# Altium schematic sheet CAO_DMX.SchDoc
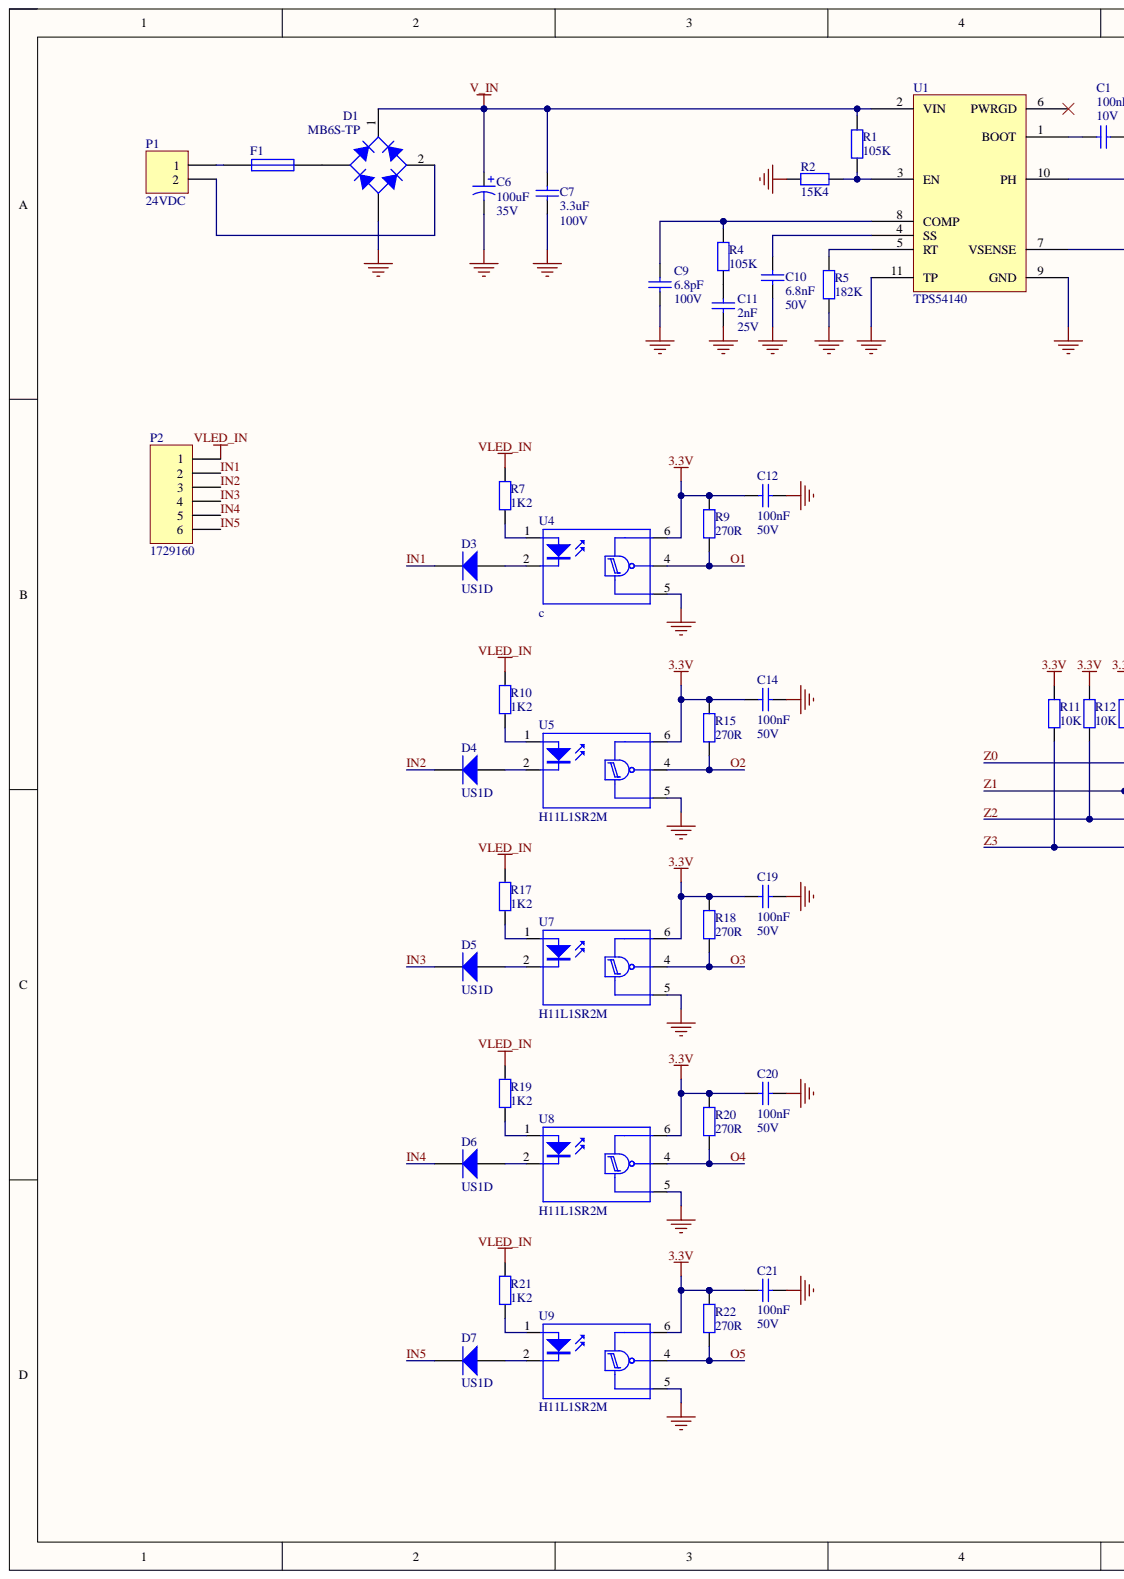
[diagram p0: CAO_DMX.SchDoc, left]
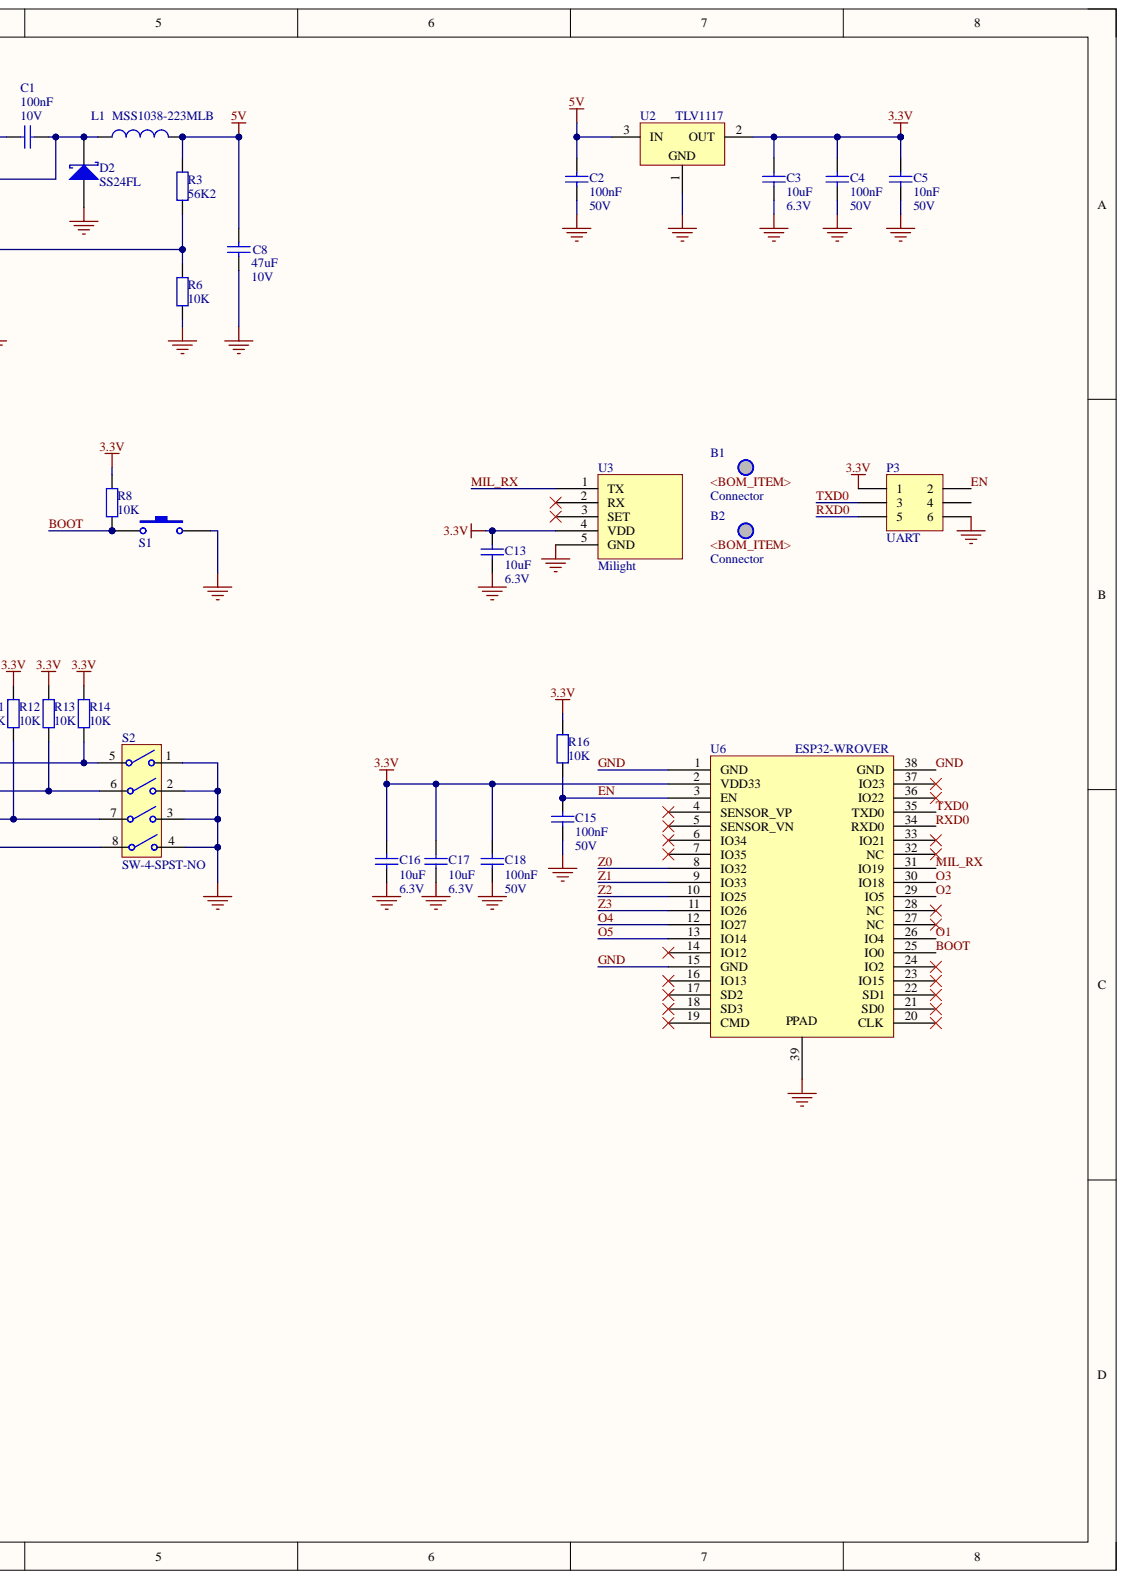
[diagram p1: CAO_DMX.SchDoc, right]

## Parts
B1  Connector  (mechanical)
B2  Connector  (mechanical)
C1  Value 1: 100nF  Value 2: 10V  [CAPC1608N]  (hidden comment: Capacitor)
C2  Value 1: 100nF  Value 2: 50V  [CAPC1608N]  (hidden comment: Capacitor)
C3  Value 1: 10uF  Value 2: 6.3V  [CAPC2012N]  (hidden comment: Capacitor)
C4  Value 1: 100nF  Value 2: 50V  [CAPC1608N]  (hidden comment: Capacitor)
C5  Value 1: 10nF  Value 2: 50V  [CAPC1608N]  (hidden comment: Capacitor)
C6  Value 1: 100uF  Value 2: 35V  [ECAP_SMD_6.3]  (hidden comment: Polarized Capacitor)
C7  Value 1: 3.3uF  Value 2: 100V  [CAPC3216N]  (hidden comment: Capacitor)
C8  Value 1: 47uF  Value 2: 10V  [CAPC2012N]  (hidden comment: Capacitor)
C9  Value 1: 6.8pF  Value 2: 100V  [CAPC1608N]  (hidden comment: Capacitor)
C10  Value 1: 6.8nF  Value 2: 50V  [CAPC1608N]  (hidden comment: Capacitor)
C11  Value 1: 2nF  Value 2: 25V  [CAPC2012N]  (hidden comment: Capacitor)
C12  Value 1: 100nF  Value 2: 50V  [CAPC1608N]  (hidden comment: Capacitor)
C13  Value 1: 10uF  Value 2: 6.3V  [CAPC2012N]  (hidden comment: Capacitor)
C14  Value 1: 100nF  Value 2: 50V  [CAPC1608N]  (hidden comment: Capacitor)
C15  Value 1: 100nF  Value 2: 50V  [CAPC1608N]  (hidden comment: Capacitor)
C16  Value 1: 10uF  Value 2: 6.3V  [CAPC2012N]  (hidden comment: Capacitor)
C17  Value 1: 10uF  Value 2: 6.3V  [CAPC2012N]  (hidden comment: Capacitor)
C18  Value 1: 100nF  Value 2: 50V  [CAPC1608N]  (hidden comment: Capacitor)
C19  Value 1: 100nF  Value 2: 50V  [CAPC1608N]  (hidden comment: Capacitor)
C20  Value 1: 100nF  Value 2: 50V  [CAPC1608N]  (hidden comment: Capacitor)
C21  Value 1: 100nF  Value 2: 50V  [CAPC1608N]  (hidden comment: Capacitor)
D1  MB6S-TP  [MB6S]
D2  SS24FL  [SOD-123F]
D3  US1D  [DO-214AC]
D4  US1D  [DO-214AC]
D5  US1D  [DO-214AC]
D6  US1D  [DO-214AC]
D7  US1D  [DO-214AC]
F1  (no value)
L1  MSS1038-223MLB  [MSS1038]
P1  24VDC  [*TE_282843-2]
P2  1729160  [1729160]
P3  UART  [HDR2X3]
R1  Value 1: 105K  [RESC1608N]  (hidden comment: Resistor)
R2  Value 1: 15K4  [RESC1608N]  (hidden comment: Resistor)
R3  Value 1: 56K2  [RESC1608N]  (hidden comment: Resistor)
R4  Value 1: 105K  [RESC1608N]  (hidden comment: Resistor)
R5  Value 1: 182K  [RESC1608N]  (hidden comment: Resistor)
R6  Value 1: 10K  [RESC1608N]  (hidden comment: Resistor)
R7  Value 1: 1K2  [RESC6432N]  (hidden comment: Resistor)
R8  Value 1: 10K  [RESC1608N]  (hidden comment: Resistor)
R9  Value 1: 270R  [RESC1608N]  (hidden comment: Resistor)
R10  Value 1: 1K2  [RESC6432N]  (hidden comment: Resistor)
R11  Value 1: 10K  [RESC1608N]  (hidden comment: Resistor)
R12  Value 1: 10K  [RESC1608N]  (hidden comment: Resistor)
R13  Value 1: 10K  [RESC1608N]  (hidden comment: Resistor)
R14  Value 1: 10K  [RESC1608N]  (hidden comment: Resistor)
R15  Value 1: 270R  [RESC1608N]  (hidden comment: Resistor)
R16  Value 1: 10K  [RESC1608N]  (hidden comment: Resistor)
R17  Value 1: 1K2  [RESC6432N]  (hidden comment: Resistor)
R18  Value 1: 270R  [RESC1608N]  (hidden comment: Resistor)
R19  Value 1: 1K2  [RESC6432N]  (hidden comment: Resistor)
R20  Value 1: 270R  [RESC1608N]  (hidden comment: Resistor)
R21  Value 1: 1K2  [RESC6432N]  (hidden comment: Resistor)
R22  Value 1: 270R  [RESC1608N]  (hidden comment: Resistor)
S1  Switch  [FSM4]  (value not drawn)
S2  SW-4-SPST-NO  [418117270904]
U1  TPS54140  [VSON-10]
U2  TLV1117  [SOT223]
U3  Milight  [MILIGHT_RF_MODULE]
U4  c  [DIP-6]
U5  H11L1SR2M  [DIP-6]
U6  ESP32-WROVER  [ESP32-WROVER]
U7  H11L1SR2M  [DIP-6]
U8  H11L1SR2M  [DIP-6]
U9  H11L1SR2M  [DIP-6]

## Nets
3.3V: C3.2 C4.2 C5.2 C12.2 C13.2 C14.2 C16.2 C17.2 C18.2 C19.2 C20.2 C21.2 P3.1 R8.2 R9.2 R11.2 R12.2 R13.2 R14.2 R15.2 R16.2 R18.2 R20.2 R22.2 U2.2 U3.4(VDD) U4.6 U5.6 U6.2(VDD33) U7.6 U8.6 U9.6
5V: C2.2 C8.2 L1.2 R3.2 U2.3
V_IN: C6.1 C7.2 D1.1 R1.2 U1.2(VIN)
VLED_IN: P2.1 R7.2 R10.2 R17.2 R19.2 R21.2
BOOT: R8.1 S1.1 U6.25(IO0)
EN: C15.2 P3.2 R16.1 U6.3(EN)
GND: C2.1 C3.1 C4.1 C5.1 C6.2 C7.1 C8.1 C9.1 C10.1 C11.1 C12.1 C13.1 C14.1 C15.1 C16.1 C17.1 C18.1 C19.1 C20.1 C21.1 D1.3 D2.1 P3.6 R2.1 R5.1 R6.1 S1.2 S2.1 S2.2 S2.3 S2.4 U1.9(GND) U1.11(TP) U2.1 U3.5(GND) U4.5 U5.5 U6.1(GND) U6.15(GND) U6.38(GND) U6.39 U7.5 U8.5 U9.5
IN1: D3.2 P2.2
IN2: D4.2 P2.3
IN3: D5.2 P2.4
IN4: D6.2 P2.5
IN5: D7.2 P2.6
MIL_RX: U3.1(TX) U6.31(IO19)
O1: R9.1 U4.4 U6.26(IO4)
O2: R15.1 U5.4 U6.29(IO5)
O3: R18.1 U6.30(IO18) U7.4
O4: R20.1 U6.12(IO27) U8.4
O5: R22.1 U6.13(IO14) U9.4
RXD0: P3.5 U6.34(RXD0)
TXD0: P3.3 U6.35(TXD0)
Z0: R14.1 S2.5 U6.8(IO32)
Z1: R13.1 S2.6 U6.9(IO33)
Z2: R12.1 S2.7 U6.10(IO25)
Z3: R11.1 S2.8 U6.11(IO26)
NetC1_1: C1.1 U1.1(BOOT)
NetC1_2: C1.2 D2.2 L1.1 U1.10(PH)
NetC9_2: C9.2 R4.2 U1.8(COMP)
NetC10_2: C10.2 U1.4(SS)
NetC11_2: C11.2 R4.1
NetD1_2: D1.2 P1.2
NetD1_4: D1.4 F1.2
NetD3_1: D3.1 U4.2
NetD4_1: D4.1 U5.2
NetD5_1: D5.1 U7.2
NetD6_1: D6.1 U8.2
NetD7_1: D7.1 U9.2
NetF1_1: F1.1 P1.1
NetR1_1: R1.1 R2.2 U1.3(EN)
NetR3_1: R3.1 R6.2 U1.7(VSENSE)
NetR5_2: R5.2 U1.5(RT)
NetR7_1: R7.1 U4.1
NetR10_1: R10.1 U5.1
NetR17_1: R17.1 U7.1
NetR19_1: R19.1 U8.1
NetR21_1: R21.1 U9.1

Not connected: P3 4; U1 6(PWRGD); U3 2(RX) 3(SET); U6 4(SENSOR_VP) 5(SENSOR_VN) 6(IO34) 7(IO35) 14(IO12) 16(IO13) 17(SD2) 18(SD3) 19(CMD) 20(CLK) 21(SD0) 22(SD1) 23(IO15) 24(IO2) 27(NC) 28(NC) 32(NC) 33(IO21) 36(IO22) 37(IO23)

## Library data of the parts
B1 = MIS-000000019: BOM item; Database Table Name: Miscellaneous; DES: 2212S-05SG-85 -  Connecteur carte-à-carte, 2.54 mm, 5 Contact(s), Embase, Série 2212S, Traversant, 1 Rangée(s); FTP: _; MAN: MULTICOMP; MANREF: 2212S-05SG-85; SUP: Farnell; SUPREF: 1593461; Value 1: 2.54mm; Value 2: 5 pins; NOTE: _; Part Number: MIS-000000019; TOL: _; Library Name: MdtPartDB.DbLib
B2 = MIS-000000019: BOM item; Database Table Name: Miscellaneous; DES: 2211S-05G -  Connecteur carte-à-carte, Vertical, 2.54 mm, 5 Contact(s), Embase, Série 2211S, Traversant; FTP: _; MAN: MULTICOMP; MANREF: 2211S-05G; SUP: Farnell; SUPREF: 1593414; Value 1: 2.54mm; Value 2: 5 pins; NOTE: _; Part Number: MIS-000000019; TOL: _; Library Name: MdtPartDB.DbLib
C1 = CAP-000000040: Database Table Name: Ceramic Capacitor; DES: C0603X104K8RACTU -  Condensateur céramique multicouche CMS, 0.1 µF, 10 V, 0603 [1608 Metric], ± 10%, X7R; FTP: 0603; MAN: KEMET; MANREF: C0603X104K8RACTU; NOTE: _; SUP: Farnell; SUPREF: 2904937; TOL: 10%; Component Kind: Standard; Description: Capacitor; Part Number: CAP-000000040; Library Name: MdtPartDB.DbLib
C2 C4 C12 C14 C15 C18-C21 = CAP-000000003: Database Table Name: Ceramic Capacitor; DES: MULTICOMP  MC0603F104Z500CT  CONDENSATEUR MLCC Y5V 100NF 0603; FTP: 0603; MAN: MULTICOMP; MANREF: MC0603F104Z500CT; NOTE: _; SUP: Farnell; SUPREF: 1759123; TOL: +80% -20%; Component Kind: Standard; Description: Capacitor; Part Number: CAP-000000003; Library Name: MdtPartDB.DbLib
C3 C13 C16 C17 = CAP-000000042: Database Table Name: Ceramic Capacitor; DES: MCTT21X106M6R3CT -  Condensateur céramique multicouche CMS, 10 µF, 6.3 V, 0805 [2012 Metric], ± 20%, X5R, Série MCTT; FTP: 0805; MAN: MULTICOMP; MANREF: MCTT21X106M6R3CT; NOTE: _; SUP: Farnell; SUPREF: 1759476; TOL: 20%; Component Kind: Standard; Description: Capacitor; Part Number: CAP-000000042; Library Name: MdtPartDB.DbLib
C5 = CAP-000000002: Database Table Name: Ceramic Capacitor; DES: MULTICOMP  MC0603B103K500CT  CONDENSATEUR MLCC X7R 10NF 50V 0603; FTP: 0603; MAN: MULTICOMP; MANREF: MC0603B103K500CT; NOTE: _; SUP: Farnell; SUPREF: 1759102; TOL: 10%; Component Kind: Standard; Description: Capacitor; Part Number: CAP-000000002; Library Name: MdtPartDB.DbLib
C6 = CAP-000000008: Database Table Name: Electrolytic Capacitors; DES: PANASONIC ELECTRONIC COMPONENTS  EEEFK1V101XP  CONDENSATEUR ELEC ALU 100UF 35V CMS; FTP: SMD Size D8; MAN: PANASONIC; MANREF: EEEFK1V101XP; NOTE: _; SUP: Farnell; SUPREF: 1850114; TOL: 20%; Component Kind: Standard; Description: Polarized Capacitor; Part Number: CAP-000000008; Library Name: MdtPartDB.DbLib
C7 = CAP-000000036: Database Table Name: Ceramic Capacitor; DES: Multilayer Ceramic Capacitors MLCC - SMD/SMT CGA 1206 100V 3.3uF X7S 20% AEC-Q200; FTP: 1206; MAN: TDK; MANREF: CGA5L3X7S2A335M160AB; NOTE: _; SUP: Mouser; SUPREF: 810-CGA5L3X7S2A335MB; TOL: 20%; Component Kind: Standard; Description: Capacitor; Part Number: CAP-000000036; Library Name: MdtPartDB.DbLib
C8 = CAP-000000041: Database Table Name: Ceramic Capacitor; DES: GRM21BR61A476ME15L -  Condensateur céramique multicouche CMS, 47 µF, 10 V, 0805 [2012 Metric], ± 20%, X5R, GRM Series; FTP: 0805; MAN: MURATA; MANREF: GRM21BR61A476ME15L; NOTE: _; SUP: Farnell; SUPREF: 2611939; TOL: 20%; Component Kind: Standard; Description: Capacitor; Part Number: CAP-000000041; Library Name: MdtPartDB.DbLib
C9 = CAP-000000037: Database Table Name: Ceramic Capacitor; DES: Multilayer Ceramic Capacitors MLCC - SMD/SMT 100V 6.8pF C0G 0603 .1pF Tol; FTP: 0603; MAN: AVX; MANREF: 06031U6R8BAT2A; NOTE: _; SUP: Mouser; SUPREF: 581-06031U6R8BAT2A; Component Kind: Standard; Description: Capacitor; Part Number: CAP-000000037; TOL: _; Library Name: MdtPartDB.DbLib
C10 = CAP-000000039: Database Table Name: Ceramic Capacitor; DES: C0603X682K5GECAUTO -  Condensateur céramique multicouche CMS, ESD, AEC-Q200, 6800 pF, 50 V, 0603 [1608 Metric], ± 10%; FTP: 0603; MAN: KEMET; MANREF: C0603X682K5GECAUTO; NOTE: _; SUP: Farnell; SUPREF: 2760504; TOL: 10%; Component Kind: Standard; Description: Capacitor; Part Number: CAP-000000039; Library Name: MdtPartDB.DbLib
C11 = CAP-000000038: Database Table Name: Ceramic Capacitor; DES: C0805C202J3GACTU -  Condensateur céramique multicouche CMS, 2000 pF, 25 V, 0805 [2012 Metric], ± 5%, C0G / NP0; FTP: 0805; MAN: KEMET; MANREF: C0805C202J3GACTU; NOTE: _; SUP: Farnell; SUPREF: 2905236; TOL: 5%; Component Kind: Standard; Description: Capacitor; Part Number: CAP-000000038; Library Name: MdtPartDB.DbLib
D1 = DIB-000000002: Full Wave Diode Bridge; Database Table Name: Diodes; DES: Redresseurs en pont .5A 600V; FTP: MBS-1; MAN: Micro Commercial Components (MCC); NOTE: _; SUP: Mouser; SUPREF: 833-MB6S-TP; Value 1: 0.5A; Value 2: 600V; Component Kind: Standard; MANREF: MB6S-TP; Part Number: DIB-000000002; TOL: _; Library Name: MdtPartDB.DbLib
D2 = DIS-000000016: Schottky Diode; Database Table Name: Diodes; DES: Schottky Diodes & Rectifiers 40V, 2A SCHOTTKY; FTP: SOD-123-2; MAN: ON Semiconductor / Fairchild; NOTE: _; SUP: Mouser; SUPREF: 512-SS24FL; Value 1: 2A; Value 2: 40V; Component Kind: Standard; MANREF: SS24FL; Part Number: DIS-000000016; TOL: _; Library Name: MdtPartDB.DbLib
D3-D7 = DIR-000000002: Diode; Database Table Name: Diodes; DES: Diode Fast / U, 200 V, 1 A, Une, 1 V, 50 ns, 30 A; MAN: MULTICOMP; NOTE: _; SUP: Farnell; SUPREF: 1625280; Value 1: 1A; Value 2: 200V; Component Kind: Standard; FTP: DO-214AC; MANREF: US1D; Part Number: DIR-000000002; TOL: _; Library Name: MdtPartDB.DbLib
F1 = Fuse: Component Kind: Standard; Description: Fuse; Library Name: GNSS.SchLib
L1 = IND-000000012: Inductor; Database Table Name: Inductors; DES: Fixed Inductors MSS1038 SMT Shielded 22 uH 20 % 2.85 A; FTP: _; MAN: Coilcraft; SUP: Mouser; SUPREF: 994-MSS1038-223MLB; TOL: 20%; Value 1: 22uH; Value 2: 2.85A; Component Kind: Standard; MANREF: MSS1038-223MLB; NOTE: _; Part Number: IND-000000012; Library Name: MdtPartDB.DbLib
P1 = CON-000000036: Header, 2-Pin; Database Table Name: Connectors; DES: Fixed Terminal Blocks WR-TBL Terminal Block - Rising Cage - THT 2 Positions 10.16 mm Pitch 14A; FTP: THT; MAN: Wurth Elektronik; MANREF: 691213610002    39910-0102; NOTE: _; SUP: Mouser; SUPREF: 710-691213610002; Value 1: 2pts; Value 2: 10.16 mm; Component Kind: Standard; Part Number: CON-000000036; TOL: _; Library Name: MdtPartDB.DbLib
P2 = CON-000000035: Header, 6-Pin; Database Table Name: Connectors; DES: Fixed Terminal Blocks 6P 5.08mm 90DEG; FTP: THT; MAN: Phoenix Contact; NOTE: _; SUP: Mouser; SUPREF: 651-1729160; Value 1: 6pts; Value 2: 5.08 mm; Component Kind: Standard; MANREF: 1729160; Part Number: CON-000000035; TOL: _; Library Name: MdtPartDB.DbLib
P3 = CON-000000012: Header, 3-Pin, Dual row; Database Table Name: Connectors; DES: Embases et logements de câbles WR-PHD 2.54mm Hdr 6P Dual Str Gold; FTP: THT; MAN: Wurth Electronics; MANREF: 61300621121; NOTE: _; SUP: Mouser; SUPREF: 710-61300621121; Value 1: 6pts; Component Kind: Standard; Part Number: CON-000000012; TOL: _; Value 2: _; Library Name: MdtPartDB.DbLib
R1 R4 = RES-000000070: Database Table Name: Resistors; DES: ERJ3EKF1053V -  Résistance à puce CMS, Couche épaisse, 105 kohm, Série ERJ3E, 75 V, Couche épaisse; FTP: 0603; MAN: PANASONIC ELECTRONIC COMPONENT; MANREF: ERJ3EKF1053V; NOTE: _; SUP: Farnell; SUPREF: 2059494; TOL: 1%; Value 2: 0.1W; Component Kind: Standard; Description: Resistor; Part Number: RES-000000070; Library Name: MdtPartDB.DbLib
R2 = RES-000000085: Database Table Name: Resistors; DES: CRCW060315K4FKEA. -  Résistance à puce CMS, Couche épaisse, 0603 [1608 Metric], 15.4 kohm, Série CRCW, 75 V; FTP: 0603; MAN: VISHAY; MANREF: CRCW060315K4FKEA; NOTE: _; SUP: Farnell; SUPREF: 2138431; TOL: 1%; Value 2: 0.1W; Component Kind: Standard; Description: Resistor; Part Number: RES-000000085; Library Name: MdtPartDB.DbLib
R3 = RES-000000086: Database Table Name: Resistors; DES: MCWR06X5622FTL -  Résistance à puce CMS, 56.2 kohm, MCWR06 Series, 75 V, Couche épaisse, 0603 [1608 Metric], 100 mW; FTP: 0603; MANREF: MCWR06X5622FTL; NOTE: _; SUP: Farnell; SUPREF: 2694903; TOL: 1%; Value 2: 0.1W; Component Kind: Standard; Description: Resistor; MAN: MCWR06X5622FTL -  Résistance à puce CMS, 56.2 kohm, MCWR06 Series, 75 V, Couche épaisse, 0603 [1608 Metric], 100 mW; Part Number: RES-000000086; Library Name: MdtPartDB.DbLib
R5 = RES-000000084: Database Table Name: Resistors; DES: ERJ3EKF1823V -  Résistance à puce CMS, Couche épaisse, 182 kohm, Série ERJ3E, 75 V, Couche épaisse; FTP: 0603; MAN: PANASONIC ELECTRONIC COMPONENTS; MANREF: ERJ3EKF1823V; NOTE: _; SUP: Farnell; SUPREF: 2059508; TOL: 1%; Value 2: 0.1W; Component Kind: Standard; Description: Resistor; Part Number: RES-000000084; Library Name: MdtPartDB.DbLib
R6 R8 R11-R14 R16 = RES-000000003: Database Table Name: Resistors; DES: YAGEO (PHYCOMP)  RC0603FR-0710KL  RESISTANCE COUCHE EPAISSE 10K 0.1W 1%; FTP: 0603; MAN: YAGEO; MANREF: RC0603FR-0710KL; NOTE: _; SUP: Farnell; SUPREF: 9238603; TOL: 1%; Value 2: 0.1W; Component Kind: Standard; Description: Resistor; Part Number: RES-000000003; Library Name: MdtPartDB.DbLib
R7 R10 R17 R19 R21 = RES-000000095: Database Table Name: Resistors; DES: ERJ1TYJ122U -  Résistance à puce CMS, Couche épaisse, 2512 [6432 Metric], 1.2 kohm, Série ERJ1T, 200 V; FTP: 2512; MAN: PANASONIC; MANREF: ERJ1TYJ122U; NOTE: _; SUP: Farnell; SUPREF: 2324174; TOL: 5%; Value 2: 1W; Component Kind: Standard; Description: Resistor; Part Number: RES-000000095; Library Name: MdtPartDB.DbLib
R9 R15 R18 R20 R22 = RES-000000094: Database Table Name: Resistors; DES: CRCW0603270RFKEA. -  Résistance à puce CMS, Couche épaisse, 0603 [1608 Metric], 270 ohm, Série CRCW, 75 V; FTP: 0603; MAN: VISHAY; MANREF: CRCW0603270RFKEA; NOTE: _; SUP: Farnell; SUPREF: 2141320; TOL: 1%; Value 2: 0.1W; Component Kind: Standard; Description: Resistor; Part Number: RES-000000094; Library Name: MdtPartDB.DbLib
S1 = MIS-000000020: Database Table Name: Miscellaneous; DES: FSM4JSMA -  Commutateur tactile, Série FSMJSMA, A déclencheur supérieur, Montage en surface, Bouton rond; FTP: _; MAN: ALCOSWITCH - TE CONNECTIVITY; MANREF: FSM4JSMA; SUP: Farnell; SUPREF: 3801305; Component Kind: Standard; Description: Switch; NOTE: _; Part Number: MIS-000000020; TOL: _; Value 1: _; Value 2: _; Library Name: MdtPartDB.DbLib
S2 = SWC-000000004: Database Table Name: Switch/Relays; DES: Commutateurs DIL/Commutateurs SIL WS-DITV DIP 4p THT 2.54mm Hrztl Box; FTP: _; MAN: Wurth Elektronik; SUP: Mouser; SUPREF: 710-418117270904; Component Kind: Standard; MANREF: 418117270904; NOTE: _; Part Number: SWC-000000004; TOL: _; Value 1: _; Value 2: _; Library Name: MdtPartDB.DbLib
U1 = ICP-000000006: 4-V to 40-V, 1-A Step-Down Converter With High Efficiency Eco-Mode; Database Table Name: Power Management IC; DES: Switching Voltage Regulators 3.5-42Vin,1.5A SD Converter; MAN: Texas Instruments; MANREF: TPS54140ADRCT; NOTE: _; SUP: Mouser; SUPREF: 595-TPS54140ADRCT; Component Kind: Standard; FTP: VSON-10; Part Number: ICP-000000006; TOL: _; Value 1: _; Value 2: _; Library Name: MdtPartDB.DbLib
U2 = VRG-000000001: Voltage Regulator; DES: TEXAS INSTRUMENTS  TLV1117LV33DCYR  LDO FIXE 3.3V 1A SOT-223-4; FTP: SOT-223; MAN: Texas Instrument; MANREF: TLV1117LV33DCYR; NOTE: _; SUP: Farnell; SUPREF: 3122356; Value 1: 3.3V; Value 2: 1A; Component Kind: Standard; Database Table Name: Voltage Regulator; Part Number: VRG-000000001; TOL: _; Library Name: MdtPartDB.DbLib
U3 = RFC-000000003: Database Table Name: RF; DES: RF Module; FTP: _; MANREF: PCB-KH01; SUP: Deliled; Component Kind: Standard; MAN: Milight; NOTE: _; Part Number: RFC-000000003; SUPREF: PCB-KH01; TOL: _; Value 1: _; Value 2: _; Library Name: MdtPartDB.DbLib
U4 U5 U7-U9 = OPT-000000005: Schmitt Trigger Output Optocoupler; Database Table Name: Optocouplers; DES: H11L1SR2M -  Optocoupleur, 1 voie, 7.5 kV, Montage en surface DIP, 6 Broche(s); MAN: ON SEMICONDUCTOR; NOTE: _; SUP: Farnell; SUPREF: 1086683; Component Kind: Standard; FTP: DIP-6; Part Number: OPT-000000005; TOL: _; Value 1: _; Value 2: _; Library Name: MdtPartDB.DbLib
  U4: MANREF: c
  U5 U7-U9: MANREF: H11L1SR2M
U6 = RFC-000000002: Modules WiFi / 802.11 SMD Module, ESP32-D0WDQ6, 32Mbits PSRAM, 32Mbits SPI flash, PCB Antenna; Database Table Name: RF; FTP: _; MAN: Espressif Systems; SUP: ASTONE TECHNOLOGY; Component Kind: Standard; DES: Modules WiFi / 802.11 SMD Module, ESP32-D0WDQ6, 32Mbits PSRAM, 32Mbits SPI flash, PCB Antenna; MANREF: ESP32-WROVER; NOTE: _; Part Number: RFC-000000002; SUPREF: ESP32-WROVER; TOL: _; Value 1: _; Value 2: _; Library Name: MdtPartDB.DbLib
